# Altium schematic sheet Programmer.SchDoc(Programmer)
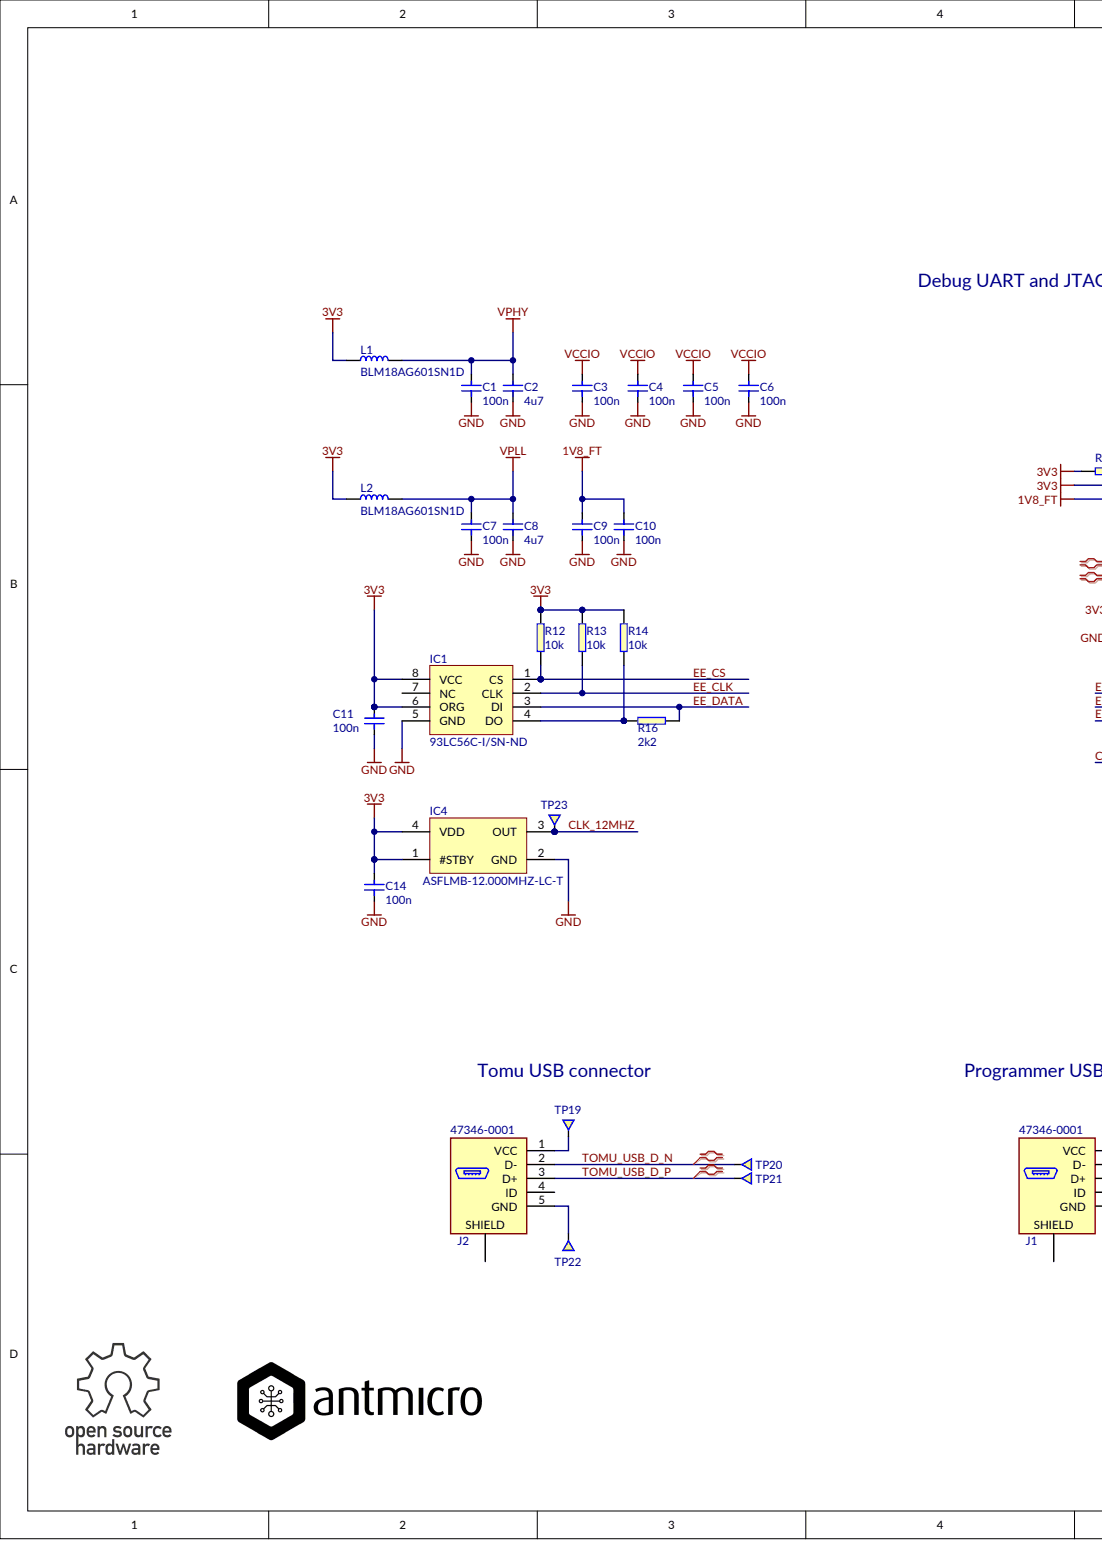
[diagram p0: Programmer.SchDoc, left]
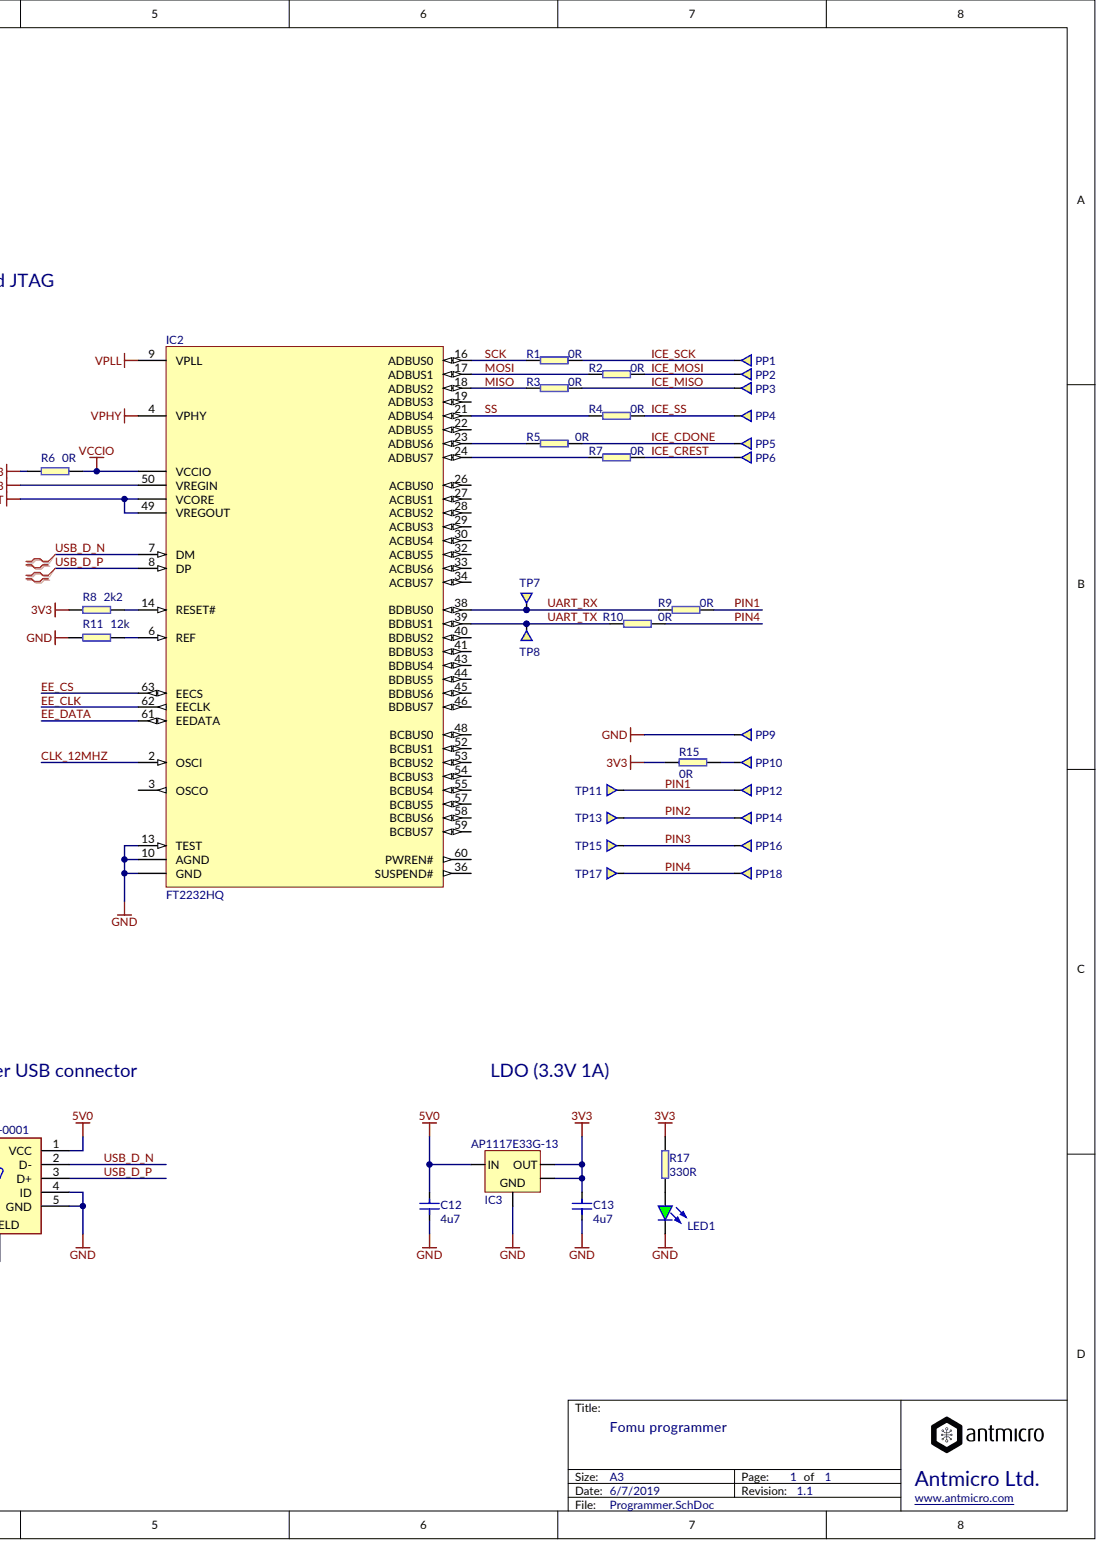
[diagram p1: Programmer.SchDoc, right]

## Parts
C1  100n
C2  4u7
C3  100n
C4  100n
C5  100n
C6  100n
C7  100n
C8  4u7
C9  100n
C10  100n
C11  100n
C12  4u7
C13  4u7
C14  100n
IC1  93LC56C-I/SN-ND
IC2  FT2232HQ
IC3  AP1117E33G-13
IC4  ASFLMB-12.000MHZ-LC-T
J1  PartSymbol: 47346-0001  (hidden comment: Molex 47346-0001)
J2  PartSymbol: 47346-0001  (hidden comment: Molex 47346-0001)
L1  BLM18AG601SN1D
L2  BLM18AG601SN1D
LED1  KPT-1608SGC  (value not drawn)
PP1  =Value
PP2  =Value
PP3  =Value
PP4  =Value
PP5  =Value
PP6  =Value
PP9  =Value
PP10  =Value
PP12  =Value
PP14  =Value
PP16  =Value
PP18  =Value
R1  0R
R2  0R
R3  0R
R4  0R
R5  0R
R6  0R
R7  0R
R8  2k2
R9  0R
R10  0R
R11  12k
R12  10k
R13  10k
R14  10k
R15  0R
R16  2k2
R17  330R
TP7  Testpad  (value not drawn)
TP8  Testpad  (value not drawn)
TP11  Testpad  (value not drawn)
TP13  Testpad  (value not drawn)
TP15  Testpad  (value not drawn)
TP17  Testpad  (value not drawn)
TP19  Testpad  (value not drawn)
TP20  Testpad  (value not drawn)
TP21  Testpad  (value not drawn)
TP22  Testpad  (value not drawn)
TP23  Testpad  (value not drawn)

## Nets
1V8_FT: C9.2 C10.2 IC2.49(VREGOUT) IC2.VCORE
3V3: C11.2 C13.2 C14.2 IC1.6(ORG) IC1.8(VCC) IC2.50(VREGIN) IC3.2(OUT) IC3.4(GND) IC4.1(#STBY) IC4.4(VDD) L1.1 L2.1 R6.1 R8.1 R12.2 R13.2 R14.2 R15.1 R17.2
5V0: C12.2 IC3.3(IN) J1.1(VCC)
GND: C1.1 C2.1 C3.1 C4.1 C5.1 C6.1 C7.1 C8.1 C9.1 C10.1 C11.1 C12.1 C13.1 C14.1 IC1.5(GND) IC2.10(AGND) IC2.13(TEST) IC2.GND IC3.1 IC4.2(GND) J1.4(ID) J1.5(GND) LED1.K PP9.1 R11.1
VCCIO: C3.2 C4.2 C5.2 C6.2 IC2.VCCIO R6.2
VPHY: C1.2 C2.2 IC2.4(VPHY) L1.2
VPLL: C7.2 C8.2 IC2.9(VPLL) L2.2
CLK_12MHZ: IC2.2(OSCI) IC4.3(OUT) TP23.1
EE_CLK: IC1.2(CLK) IC2.62(EECLK) R13.1
EE_CS: IC1.1(CS) IC2.63(EECS) R12.1
EE_DATA: IC1.3(DI) IC2.61(EEDATA) R16.2
ICE_CDONE: PP5.1 R5.2
ICE_CREST: PP6.1 R7.2
ICE_MISO: PP3.1 R3.2
ICE_MOSI: PP2.1 R2.2
ICE_SCK: PP1.1 R1.2
ICE_SS: PP4.1 R4.2
MISO: IC2.18(ADBUS2) R3.1
MOSI: IC2.17(ADBUS1) R2.1
PIN1: PP12.1 R9.2 TP11.1
PIN2: PP14.1 TP13.1
PIN3: PP16.1 TP15.1
PIN4: PP18.1 R10.2 TP17.1
SCK: IC2.16(ADBUS0) R1.1
SS: IC2.21(ADBUS4) R4.1
TOMU_USB_D_N: J2.2(D-) TP20.1
TOMU_USB_D_P: J2.3(D+) TP21.1
UART_RX: IC2.38(BDBUS0) R9.1 TP7.1
UART_TX: IC2.39(BDBUS1) R10.1 TP8.1
USB_D_N: IC2.7(DM) J1.2(D-)
USB_D_P: IC2.8(DP) J1.3(D+)
NetIC1_4: IC1.4(DO) R14.1 R16.1
NetIC2_6: IC2.6(REF) R11.2
NetIC2_14: IC2.14(RESET#) R8.2
NetIC2_23: IC2.23(ADBUS6) R5.1
NetIC2_24: IC2.24(ADBUS7) R7.1
NetJ2_1: J2.1(VCC) TP19.1
NetJ2_5: J2.5(GND) TP22.1
NetLED1_A: LED1.A R17.1
NetPP10_1: PP10.1 R15.2

Not connected: IC1 7(NC); IC2 3(OSCO) 19(ADBUS3) 22(ADBUS5) 26(ACBUS0) 27(ACBUS1) 28(ACBUS2) 29(ACBUS3) 30(ACBUS4) 32(ACBUS5) 33(ACBUS6) 34(ACBUS7) 36(SUSPEND#) 40(BDBUS2) 41(BDBUS3) 43(BDBUS4) 44(BDBUS5) 45(BDBUS6) 46(BDBUS7) 48(BCBUS0) 52(BCBUS1) 53(BCBUS2) 54(BCBUS3) 55(BCBUS4) 57(BCBUS5) 58(BCBUS6) 59(BCBUS7) 60(PWREN#); J1 S; J2 4(ID) S

## Library data of the parts
C1 C3-C7 C9-C11 C14 = (no symbol name): PartNumber: C_100n_0402; Manufacturer: AVX; PartSymbol: 0402YC104KAT2A; Value: 100n
C2 C8 C12 C13 = (no symbol name): PartNumber: C_4u7_0402; Manufacturer: TDK; PartSymbol: C1005X5R0J475M050BC; Value: 4u7
IC1 = (no symbol name): Manufacturer: Microchip Technology; PartNumber: 93LC56C; Value: 93LC56C-I/SN-ND; PartSymbol: 93LC56C-I/SN-ND
IC2 = (no symbol name): Manufacturer: FTDI; Value: FT2232HQ; PartSymbol: FT2232HQ; PartNumber: FT2232HQ
IC3 = (no symbol name): Manufacturer: DIODES INC.; PartNumber: AP1117E33G-13; PartSymbol: AP1117E33G-13; Value: AP1117E33G-13
IC4 = (no symbol name): Manufacturer: Abracon LLC; PartNumber: ASFLMB-12.000MHZ-LC-T; Value: ASFLMB-12.000MHZ-LC-T; PartSymbol: ASFLMB-12.000MHZ-LC-T
J1 J2 = (no symbol name): PartNumber: CON-Molex-47346-0001; Value: Molex 47346-0001; Manufacturer: Molex
L1 L2 = (no symbol name): Manufacturer: MURATA; PartNumber: BLM18AG601SN1D; Value: BLM18AG601SN1D; PartSymbol: BLM18AG601SN1D
LED1 = (no symbol name): PartNumber: LED_G; Manufacturer: KINGBRIGHT; Value: KPT-1608SGC; PartSymbol: KPT-1608SGC
PP1-PP6 PP9 PP10 PP12 PP14 PP16 PP18 = (no symbol name): PartNumber: P70-2300045R; Manufacturer: Harwin; PartSymbol: P70-2300045R
R1-R7 R9 R10 R15 = (no symbol name): PartNumber: R_0R_0402; Manufacturer: Vishay; PartSymbol: CRCW04020000Z0ED; Value: 0R
R8 R16 = (no symbol name): PartNumber: R_2k2_0402; Manufacturer: Vishay; PartSymbol: CRCW04022K20FKED; Value: 2k2
R11 = (no symbol name): PartNumber: R_12k_0402; Manufacturer: Multicomp; PartSymbol: MCWR04X1202FTL; Value: 12k
R12-R14 = (no symbol name): PartNumber: R_10k_0402; Manufacturer: Vishay; PartSymbol: CRCW040210K0FKED; Value: 10k
R17 = (no symbol name): PartNumber: R_330R_0402; Manufacturer: Multicomp; PartSymbol: MCMR04X3300FTL; Value: 330R
TP7 TP8 TP11 TP13 TP15 TP17 TP19-TP23 = (no symbol name): PartNumber: TP-R-1.5
